# Altium schematic sheet DRIVE.SchDoc(DRIVE)
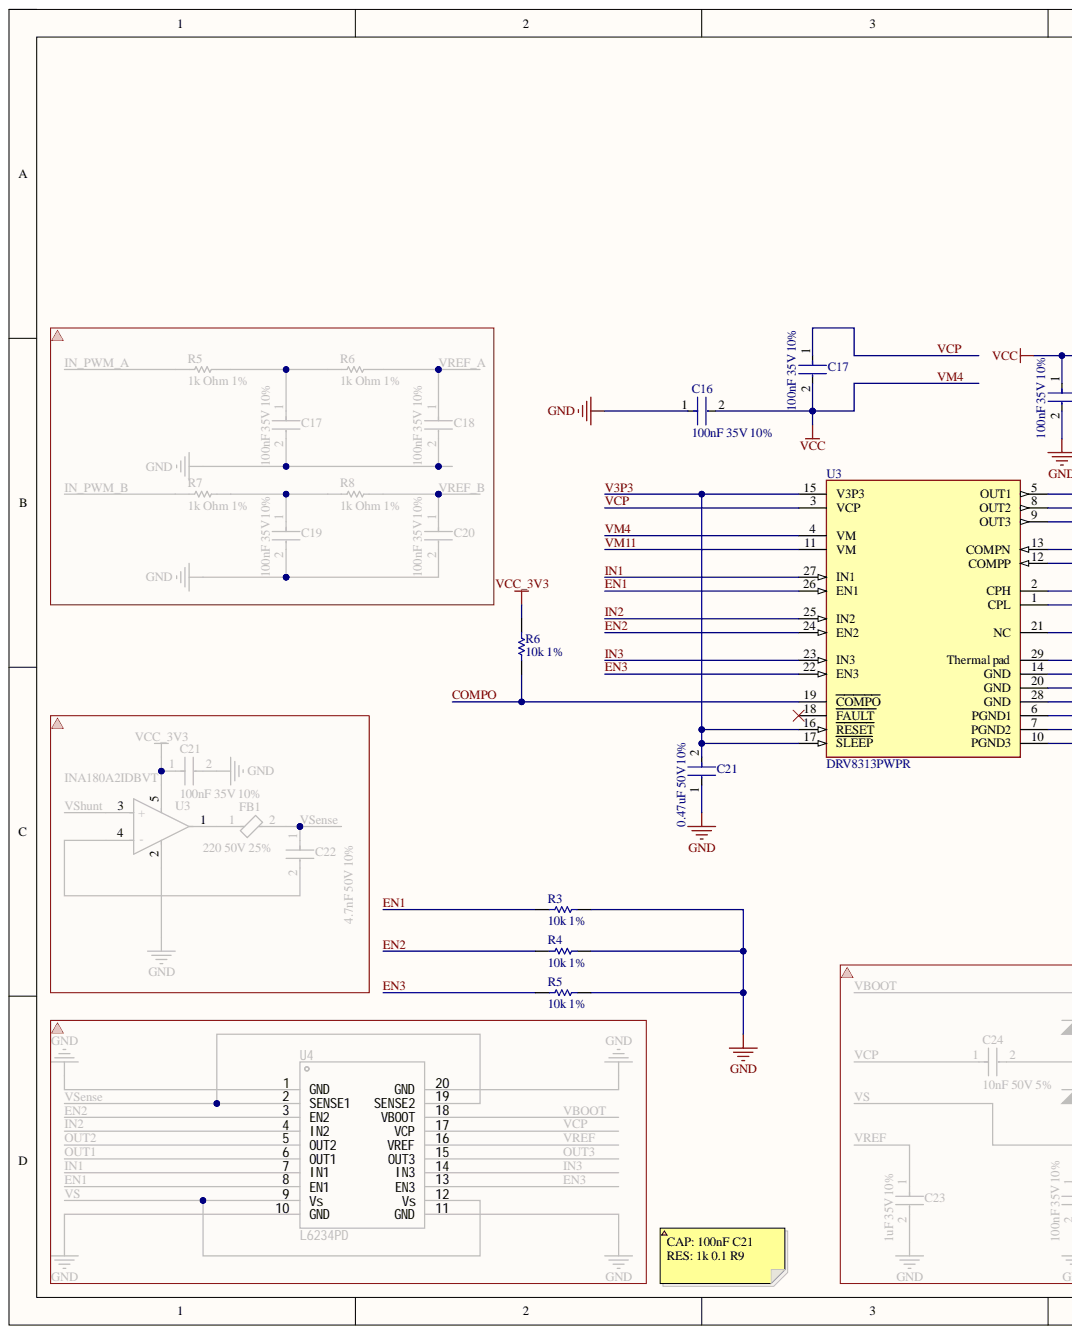
[diagram p0: DRIVE.SchDoc, left]
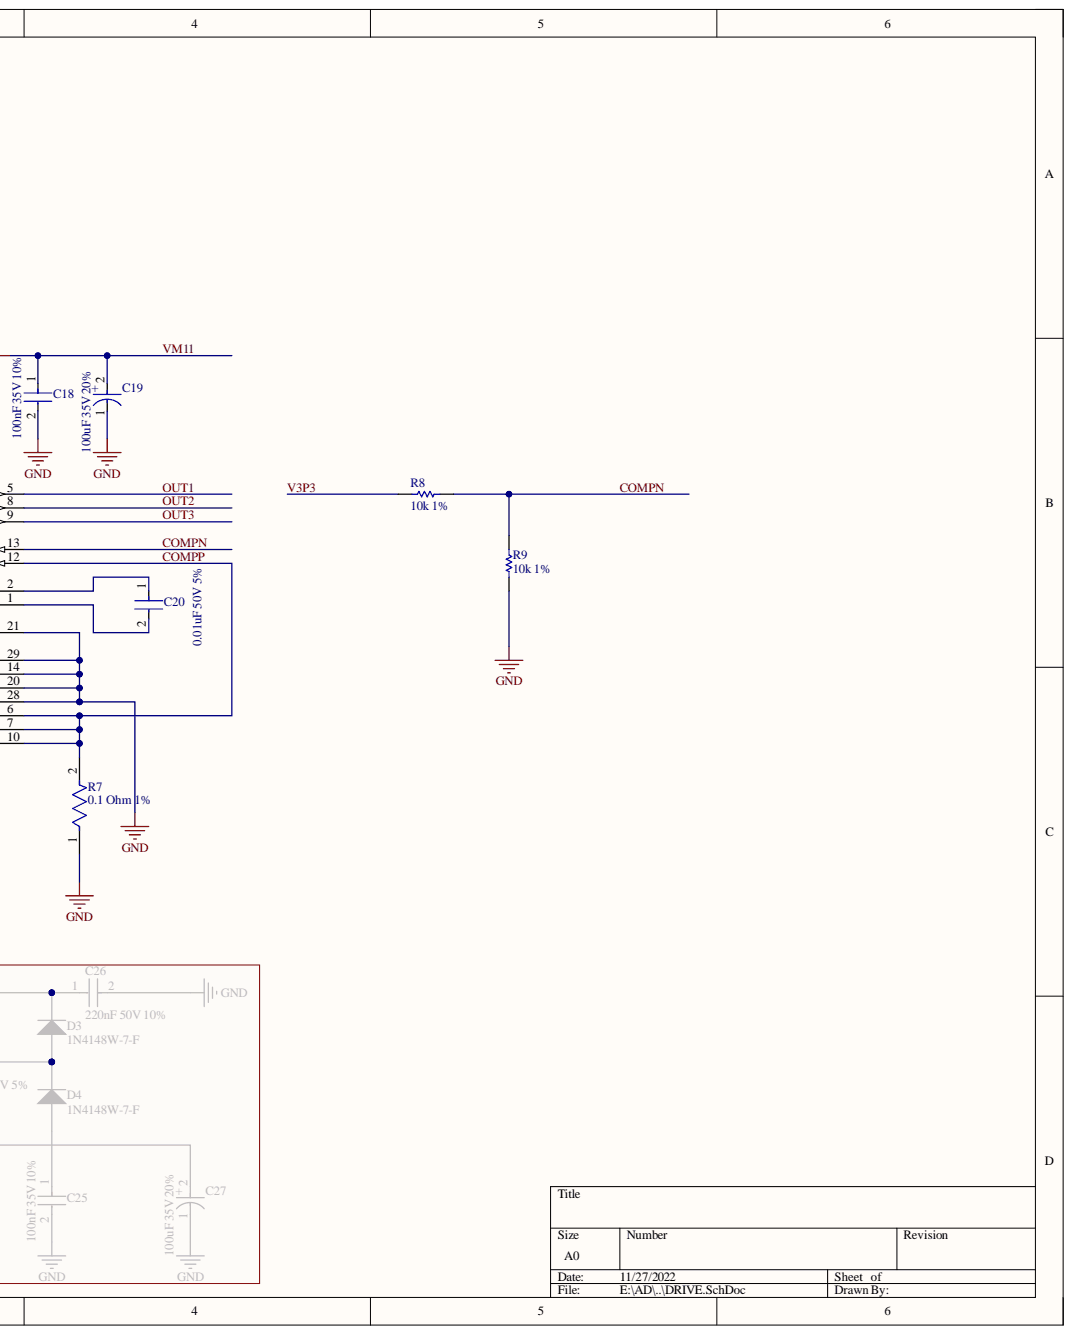
[diagram p1: DRIVE.SchDoc, right]

## Parts
C16  100nF 35V 10%  Capacitance: 100nF  Tolerance: 10%  Voltage: 35V  [FP-0402-L_1_0_0_05-W_0_5-IPC_A]
C17  100nF 35V 10%  Capacitance: 100nF  Tolerance: 10%  Voltage: 35V  [FP-0402-L_1_0_0_05-W_0_5-IPC_A]
C18  100nF 35V 10%  Capacitance: 100nF  Tolerance: 10%  Voltage: 35V  [FP-0402-L_1_0_0_05-W_0_5-IPC_A]
C19  100uF 35V 20%  Capacitance: 100uF  Tolerance: 20%  Voltage: 35V  [FP-FTD-MFG]
C20  0.01uF 50V 5%  [FP-C0805C-DN-MFG]
C21  0.47uF 50V 10%  Tolerance: 10%  Voltage: 50V  [FP-C1608-080-0_1-IPC_A]
R3  10k 1%  [RESC2013X70X40ML20T20]
R4  10k 1%  [RESC2013X70X40ML20T20]
R5  10k 1%  [RESC2013X70X40ML20T20]
R6  10k 1%  [RESC2013X70X40ML20T20]
R7  0.1 Ohm 1%  [FP-ERJ8BW-MFG]
R8  10k 1%  [RESC2013X70X40ML20T20]
R9  10k 1%  [RESC2013X70X40ML20T20]
U3  DRV8313PWPR  [FP-PWP0028C-IPC_B]

## Nets
GND: C16.1 C18.2 C19.1 C21.1 R3.2 R4.2 R5.2 R7.1 R9.1 U3.14(GND) U3.20(GND) U3.21(NC) U3.28(GND) U3.29(Thermal_pad)
VCC_3V3: R6.2
COMPN: R8.2 R9.2 U3.13(COMPN)
COMPO: R6.1 U3.19(COMPO)
COMPP: R7.2 U3.6(PGND1) U3.7(PGND2) U3.10(PGND3) U3.12(COMPP)
EN1: R3.1 U3.26(EN1)
EN2: R4.1 U3.24(EN2)
EN3: R5.1 U3.22(EN3)
IN1: U3.27(IN1)
IN2: U3.25(IN2)
IN3: U3.23(IN3)
OUT1: U3.5(OUT1)
OUT2: U3.8(OUT2)
OUT3: U3.9(OUT3)
V3P3: C21.2 R8.1 U3.15(V3P3) U3.16(RESET) U3.17(SLEEP)
VCP: C17.1 U3.3(VCP)
VM4: C16.2 C17.2 C18.1 C19.2 U3.4(VM) U3.11(VM)
NetC20_1: C20.1 U3.2(CPH)
NetC20_2: C20.2 U3.1(CPL)

Not connected: U3 18(FAULT)

## Library data of the parts
C16-C18 = CMP-2000-06323-3: Dielectric: X5R; Case Code (Imperial): 0402; Case Code (Metric): 1005; Case/Package: 0402; Component Kind: Standard; Construction: Flat; Depth: 0.5mm; Description: 100nF 35V 10%; Halogen Free: Halogen Free; Height: 0.5mm; Length: 1mm; Library Name: Altium Content Vault; Max Operating Temperature: 85°C; Min Operating Temperature: -55°C; Mount: Surface Mount; Number of Pins: 2; Package Quantity: 1; Packaging: Tape and Reel; Radiation Hardening: No; REACH SVHC: No SVHC; Resistor Type: Ceramic; RoHS Compliant: Yes; Series: M; Simulation: GMK105BJ104_V-F; Termination: SMD/SMT; Thickness: 0.022inch; Voltage Rating: 35V; Voltage Rating (DC): 35V; Width: 0.02inch
C19 = CMP-05427-000027-1: CAP ALUM 100UF 20% 35V SMD; ESR (Equivalent Series Resistance): 260mR; Lead Diameter: 0.65mm; Leakage Current: 35uA; Life (Hours): 2000Hour; Polarity: Polar; Ripple Current: 300mA; Case/Package: Radial; Component Kind: Standard; Construction: Cylindrical; Contact Plating: Tin; Diameter: 6.3mm; Height: 5.8mm; Height - Seated (Max): 0.24inch; Lead Pitch: 1.8mm; Length: 5.8mm; Library Name: Altium Content Vault; Max Operating Temperature: 105°C; Min Operating Temperature: -55°C; Mount: Surface Mount; Number of Pins: 2; Package Quantity: 1000; Packaging: Tape and Reel; Radiation Hardening: No; REACH SVHC: No SVHC; Ripple Current (AC): 300mA; RoHS Compliant: Yes; Series: FT; Termination: SMD/SMT; Voltage Rating: 35V; Voltage Rating (DC): 35V
C20 = CMP-2007-00254-2: CAP CER 10000PF 50V X7R 0805; Manufacturer: KEMET; Manufacturer Part Number: C0805C103J5RACTU; Capacitance: 10,000 pF; Material: Ceramic; Temperature Coefficient: 30ppm/°C; Tolerance: 0.05; Value: 10,000 pF; Automotive: No; Category: Cap; Component Kind: Standard; ComponentLink2Description: Datasheet; ComponentLink2URL: https://content.kemet.com/datasheets/KEM_C1003_C0G_SMD.pdf; Device Class L1: Passive Components; Device Class L2: Capacitors; Device Class L3: Ceramic Capacitors; Digikey Description: CAP CER 10000PF 50V X7R 0805; Height: 0.88mm; Ipc Land Pattern Name: CAPC200125X78; Lead Free: Yes; Library Name: Altium Content Vault; Mouser Description: Capacitor; Ceramic; Cap 0.01uF; Tol 5%; Vol-Rtg 50 V; SMT; Case 0805; X7R; TR; Octopart: https://octopart.com/c0805c103j5ractu-kemet-133473; Package: C0805C-DN; RoHS: TRUE; Temperature: -55°C to +125°C; Temperature Characteristic: X7R; Temperature Range High: +125°C; Temperature Range Low: -55°C; Voltage Rating: 50 V
C21 = CMP-08246-003628-1: Multilayer Ceramic Capacitors 470nF ±10% 50V X7R SMD 0603; Capacitance: 470nF; Case Code (Imperial): 0603; Case Code (Metric): 1608; Case/Package: 0603; Component Kind: Standard; Construction: Flat; Depth: 0.8mm; Dielectric: X7R; Dielectric Material: Ceramic; Height: 0.8mm; Lead Free: Lead Free; Length: 1.6mm; Library Name: Altium Content Vault; Max Operating Temperature: 125°C; Min Operating Temperature: -55°C; Mount: Surface Mount; Package Quantity: 4000; Packaging: Cut Tape; Radiation Hardening: No; REACH SVHC: No SVHC; Resistor Type: Ceramic; RoHS Compliant: Yes; Series: C; Termination: SMD/SMT; Thickness: 0.037inch; Voltage Rating: 50V; Voltage Rating (DC): 50V; Weight: 7.1E-05oz; Width: 0.031inch
R3-R6 R8 R9 = CMP-2001-04376-1: Manufacturer: Panasonic; Part Number: ERJ-6ENF1002V; Component Kind: Standard; Library Name: Altium Content Vault
R7 = CMP-2003-00785-2: RES 0.1 OHM 1% 1W 1206; Resistance: 100mR; Temperature Coefficient: 100ppm/°C; Case Code (Imperial): 1206; Case Code (Metric): 3216; Case/Package: 1206; Component Kind: Standard; Construction: Rectangular; Contact Plating: Tin; Depth: 1.6mm; Features: Automotive AEC-Q200; Height: 0.65mm; Lead Free: Lead Free; Length: 3.2mm; Library Name: Altium Content Vault; Max Operating Temperature: 155°C; Max Power Dissipation: 1W; Military Standard: Not; Min Operating Temperature: -55°C; Mount: Surface Mount; Number of Pins: 2; Number of Terminations: 2; Package Quantity: 1; Packaging: Tape and Reel; Power Rating: 1W; Radiation Hardening: No; REACH SVHC: No SVHC; Resistance Tolerance: 1%; Resistor Type: Thick Film; RoHS Compliant: Yes; Series: ERJ; Size Code: 1206; Technology: THICK FILM; Termination: SMD/SMT; Tolerance: 1%; Width: 0.063inch
U3 = CMP-04915-000025-1: IC MOTOR DRIVER 8V-60V 28HTSSOP; Interface: Parallel; Logic Function: AND GATE; Max Supply Voltage: 60V; Min Supply Voltage: 8V; Number of Outputs: 1; Output Current: 1.75A; Case/Package: HTSSOP; Component Kind: Standard; Lead Free: Lead Free; Library Name: Altium Content Vault; Max Operating Temperature: 125°C; Min Operating Temperature: -40°C; Mount: Surface Mount; Number of Pins: 28; Package Quantity: 2000; Packaging: Tape and Reel; Series: -
